# Altium schematic sheet USB.SchDoc
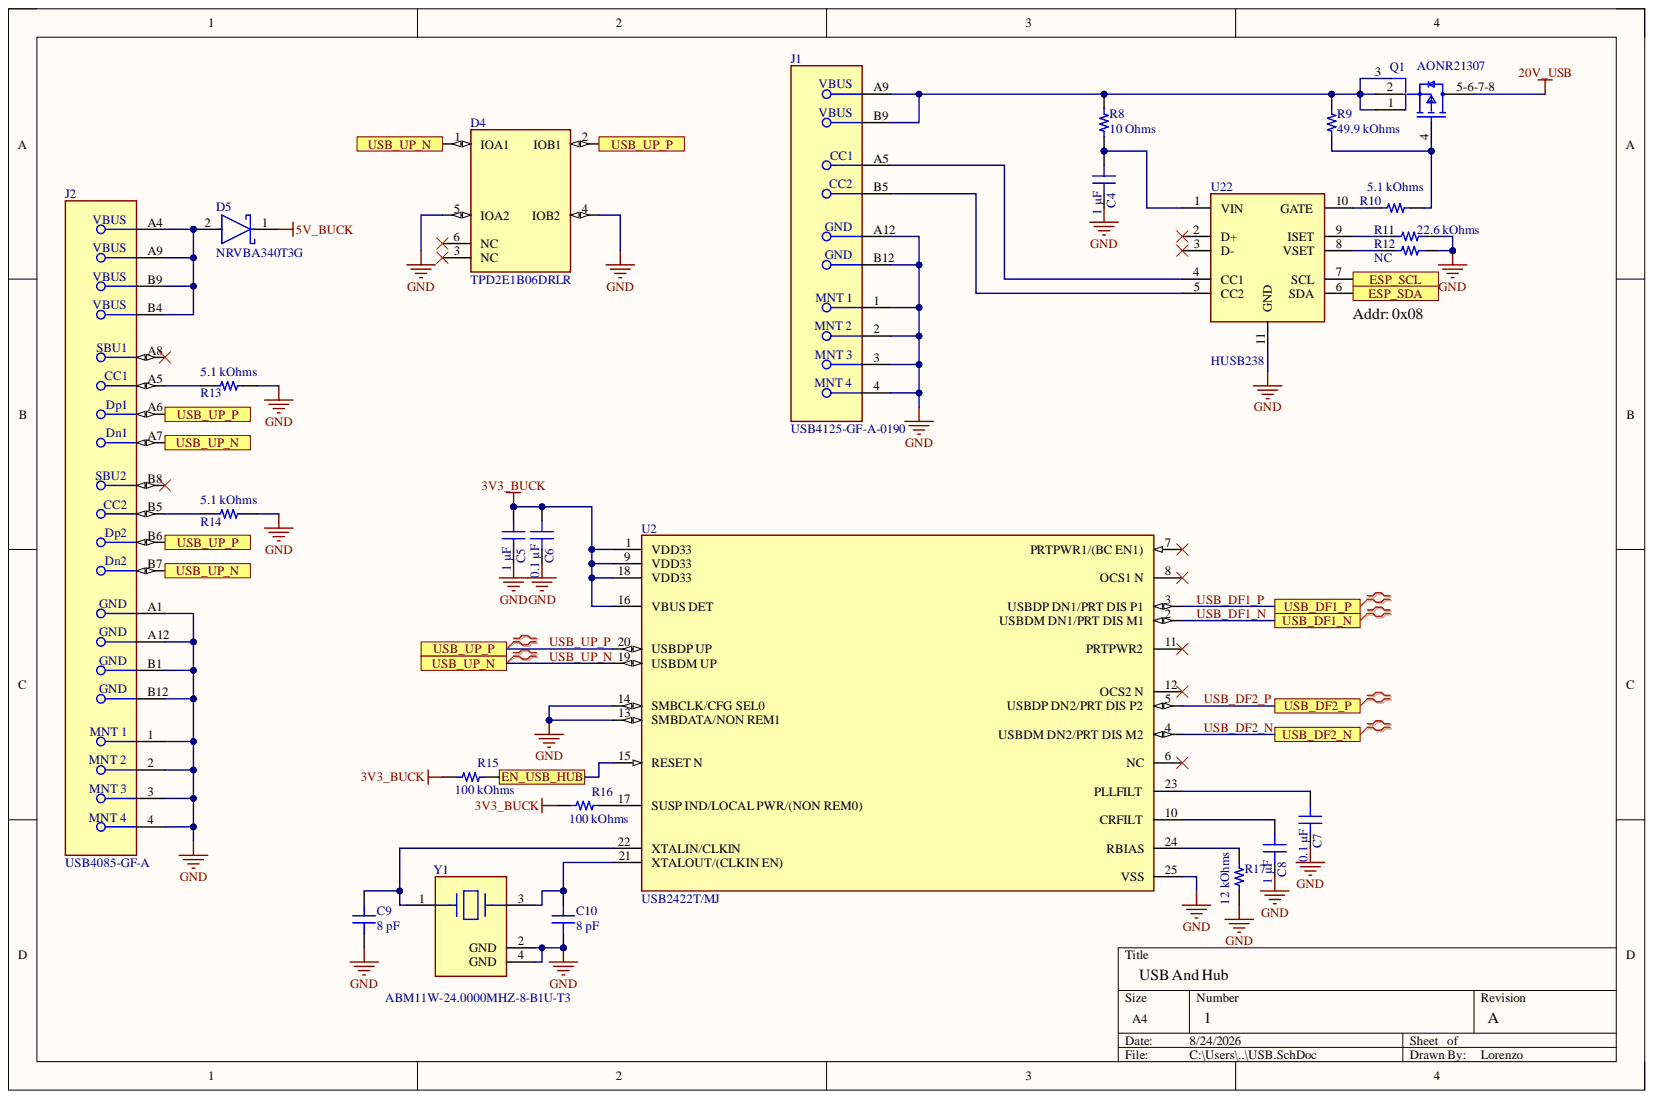
[diagram p0: USB.SchDoc]

## Parts
C4  1 µF  [CAP 0603_1608]
C5  1 µF  [CAP 0603_1608]
C6  0.1 µF  [CAP 0603_1608]
C7  0.1 µF  [CAP 0603_1608]
C8  1 µF  [CAP 0603_1608]
C9  8 pF  [CAP 0603_1608]
C10  8 pF  [CAP 0603_1608]
D4  TPD2E1B06DRLR  [FP-DRL0006A-MFG]
D5  NRVBA340T3G  [FP-403D-MFG]
J1  USB4125-GF-A-0190  [FP-USB4125-GF-A-0190-MFG]
J2  USB4085-GF-A  [FP-USB4085-GF-A-MFG]
Q1  AONR21307  [FP-DFN3x3A_8L_EP1_P-MFG]
R8  10 Ohms  [RES 0603_1608]
R9  49.9 kOhms  [RES 0603_1608]
R10  5.1 kOhms  [RES 0603_1608]
R11  22.6 kOhms  [RES 0603_1608]
R12  NC  [RES 0603_1608]
R13  5.1 kOhms  [RES 0603_1608]
R14  5.1 kOhms  [RES 0603_1608]
R15  100 kOhms  [RES 0603_1608]
R16  100 kOhms  [RES 0603_1608]
R17  12 kOhms  [RES 0603_1608]
U2  USB2422T/MJ  [FP-24-S4QFN-4x4B-0_5P-IPC_C]
U22  HUSB238  [DFN10]
Y1  ABM11W-24.0000MHZ-8-B1U-T3  [FP-ABM11W-MFG]

## Ports
EN_USB_HUB, ESP_SCL, ESP_SDA, USB_DF1_N, USB_DF1_P, USB_DF2_N, USB_DF2_P, USB_UP_N, USB_UP_P

## Nets
3V3_BUCK: C5.2 C6.2 R15.1 R16.1 U2.1(VDD33) U2.9(VDD33) U2.16(VBUS_DET) U2.18(VDD33)
5V_BUCK: D5.1
20V_USB: Q1.5-6-7-8
GND: C4.1 C5.1 C6.1 C7.1 C8.1 C9.1 C10.1 D4.4(IOB2) D4.5(IOA2) J1.1 J1.2 J1.3 J1.4 J1.A12 J1.B12 J2.1 J2.2 J2.3 J2.4 J2.A1 J2.A12 J2.B1 J2.B12 R11.2 R12.2 R13.2 R14.2 R17.1 U2.13(SMBDATA/NON_REM1) U2.14(SMBCLK/CFG_SEL0) U2.25(VSS) U22.11(GND) Y1.2(GND) Y1.4(GND)
EN_USB_HUB: R15.2 U2.15(RESET_N) ; port EN_USB_HUB
ESP_SCL: U22.7(SCL) ; port ESP_SCL
ESP_SDA: U22.6(SDA) ; port ESP_SDA
USB_DF1_N: U2.2(USBDM_DN1/PRT_DIS_M1) ; port USB_DF1_N
USB_DF1_P: U2.3(USBDP_DN1/PRT_DIS_P1) ; port USB_DF1_P
USB_DF2_N: U2.4(USBDM_DN2/PRT_DIS_M2) ; port USB_DF2_N
USB_DF2_P: U2.5(USBDP_DN2/PRT_DIS_P2) ; port USB_DF2_P
USB_UP_N: D4.1(IOA1) J2.A7 J2.B7 U2.19(USBDM_UP) ; port USB_UP_N
USB_UP_P: D4.2(IOB1) J2.A6 J2.B6 U2.20(USBDP_UP) ; port USB_UP_P
NetC4_2: C4.2 R8.1 U22.1(VIN)
NetC7_2: C7.2 U2.23(PLLFILT)
NetC8_2: C8.2 U2.10(CRFILT)
NetC9_2: C9.2 U2.22(XTALIN/CLKIN) Y1.1
NetC10_2: C10.2 U2.21(XTALOUT/(CLKIN_EN)) Y1.3
NetD5_2: D5.2 J2.A4 J2.A9 J2.B4 J2.B9
NetJ1_A5: J1.A5 U22.4(CC1)
NetJ1_A9: J1.A9 J1.B9 Q1.1 Q1.2 Q1.3 R8.2 R9.2
NetJ1_B5: J1.B5 U22.5(CC2)
NetJ2_A5: J2.A5 R13.1
NetJ2_B5: J2.B5 R14.1
NetQ1_4: Q1.4 R9.1 R10.2
NetR10_1: R10.1 U22.10(GATE)
NetR11_1: R11.1 U22.9(ISET)
NetR12_1: R12.1 U22.8(VSET)
NetR16_2: R16.2 U2.17(SUSP_IND/LOCAL_PWR/(NON_REM0))
NetR17_2: R17.2 U2.24(RBIAS)

Not connected: D4 3(NC) 6(NC); J2 A8 B8; U2 6(NC) 7(PRTPWR1/(BC_EN1)) 8(OCS1_N) 11(PRTPWR2) 12(OCS2_N); U22 2(D+) 3(D-)

## Library data of the parts
C4 C5 C8 = C1608X5R1H105K080AB: CAP CER 1UF 50V X5R 0603; manufacturer: TDK Corporation; Database Table Name: Capacitors - Ceramic - 0603; Tolerance: ±10%; Applications: General Purpose; Capacitance: 1 µF; Component Kind: Standard; componentlink1description: Datasheet; componentlink1url: https://product.tdk.com/info/en/catalog/datasheets/mlcc_commercial_general_en.pdf?ref_disty=digikey; componentlink2description: DigiKey Link; componentlink2url: https://www.digikey.com/en/products/detail/tdk-corporation/C1608X5R1H105K080AB/2732903; Device Package: 0603 (1608 Metric); Features: Low ESL; lastupdated: 09/02/2024 20:10:02; Library Name: Altium Database Library V2 Local.DbLib; Lifecycle Status: Active; Minimum Order: 1; Mounting Type: Surface Mount, MLCC; Operating Temperature: -55°C ~ 85°C; Package / Case: 0603 (1608 Metric); packaging: TapeAndReel; Part Number: C1608X5R1H105K080AB; Part Status: Active; partid: 36337; price: 0.02; series: C; Size / Dimension: 0.063" L x 0.031" W (1.60mm x 0.80mm); Supplier 1: DigiKey; Supplier Part Number 1: 445-7468-1-ND; Temperature Coefficient: X5R; Thickness (Max): 0.035" (0.90mm); Value: 1 µF; Voltage - Rated: 50V
C6 C7 = CGA3E2X7R1H104M080AE: CAP CER 0.1UF 50V X7R 0603; manufacturer: TDK Corporation; Database Table Name: Capacitors - Ceramic - 0603; Tolerance: ±20%; Applications: Automotive, Boardflex Sensitive; Capacitance: 0.1 µF; Component Kind: Standard; componentlink1description: Datasheet; componentlink1url: https://product.tdk.com/info/en/catalog/datasheets/mlcc_automotive_soft_en.pdf?ref_disty=digikey; componentlink2description: DigiKey Link; componentlink2url: https://www.digikey.com/en/products/detail/tdk-corporation/CGA3E2X7R1H104M080AE/4490595; Device Package: 0603 (1608 Metric); Features: Soft Termination; lastupdated: 09/02/2024 20:10:15; Library Name: Altium Database Library V2 Local.DbLib; Lifecycle Status: Active; Minimum Order: 1; Mounting Type: Surface Mount, MLCC; Operating Temperature: -55°C ~ 125°C; Package / Case: 0603 (1608 Metric); packaging: TapeAndReel; Part Number: CGA3E2X7R1H104M080AE; Part Status: Active; partid: 13634; price: 0.03; Ratings: AEC-Q200; series: CGA; Size / Dimension: 0.063" L x 0.031" W (1.60mm x 0.80mm); Supplier 1: DigiKey; Supplier Part Number 1: 445-15958-1-ND; Temperature Coefficient: X7R; Thickness (Max): 0.037" (0.95mm); Value: 0.1 µF; Voltage - Rated: 50V
C9 C10 = CGA3E2C0G1H080D080AA: CAP CER 8PF 50V C0G 0603; manufacturer: TDK Corporation; Database Table Name: Capacitors - Ceramic - 0603; Temperature Coefficient: C0G, NP0; Tolerance: ±0.5pF; Applications: Automotive; Capacitance: 8 pF; Component Kind: Standard; componentlink1description: Datasheet; componentlink1url: https://product.tdk.com/info/en/catalog/datasheets/mlcc_automotive_general_en.pdf?ref_disty=digikey; componentlink2description: DigiKey Link; componentlink2url: https://www.digikey.com/en/products/detail/tdk-corporation/CGA3E2C0G1H080D080AA/2443104; Device Package: 0603 (1608 Metric); lastupdated: 09/02/2024 20:10:12; Library Name: Altium Database Library V2 Local.DbLib; Lifecycle Status: Active; Minimum Order: 1; Mounting Type: Surface Mount, MLCC; Operating Temperature: -55°C ~ 125°C; Package / Case: 0603 (1608 Metric); packaging: TapeAndReel; Part Number: CGA3E2C0G1H080D080AA; Part Status: Active; partid: 15270; price: 0.01; Ratings: AEC-Q200; series: CGA; Size / Dimension: 0.063" L x 0.031" W (1.60mm x 0.80mm); Supplier 1: DigiKey; Supplier Part Number 1: 445-5626-1-ND; Thickness (Max): 0.035" (0.90mm); Value: 8 pF; Voltage - Rated: 50V
D4 = CMP-04985-000003-1: TVS DIODE 5.5V 15V 6SOT; Manufacturer: Texas Instruments; Breakdown Voltage: 7V; Direction: Bidirectional; Interface: I2C,USB,LVDS,Other; Number Of Channels: 2; Peak Pulse Current: 2.5A; Peak Pulse Power: 35W; Standoff Height: 0mm; Ambient Temperature Range High: +125°C; Ambient Temperature Range Low: -40°C; Automotive: No; Category: Diode; Clamping Voltage: 15V; Component Kind: Standard; ComponentLink2Description: Datasheet; ComponentLink2URL: http://www.ti.com/lit/ds/symlink/tpd2e1b06.pdf; Device Class L1: Circuit Protection; Device Class L2: ESD and Circuit Protection ICs; Device Class L3: unset; Digikey Description: TVS DIODE 5.5V 15V 6SOT; Height: 0.6mm; Lead Free: Yes; Library Name: Altium Content Vault; Manufacturer Part Number: TPD2E1B06DRLR; Mouser Description: ESD Suppressors / TVS Diodes 2-CH system level ESD protect device; Octopart: https://octopart.com/tpd2e1b06drlr-texas+instruments-30488860; Package: DRL0006A; Reverse Standoff Voltage: 5.5V; RoHS: TRUE; Temperature: -40°C to +125°C; Temperature Range High: +125°C; Temperature Range Low: -40°C
D5 = CMP-1055-00307-2: DIODE SCHOTTKY 40V 3A SMA; Element Configuration: Single; Forward Voltage: 450mV; Max Forward Surge Current (Ifsm): 100A; Max Reverse Current: 15mA; Average Rectified Current: 3A; Case/Package: SMA; Component Kind: Standard; Forward Current: 3A; Halogen Free: Halogen Free; Height: 2.05mm; Lead Free: Lead Free; Length: 4.57mm; Library Name: Altium Content Vault; Max Operating Temperature: 150°C; Max Repetitive Reverse Voltage (Vrrm): 40V; Max Reverse Voltage (DC): 40V; Min Operating Temperature: -55°C; Number of Pins: 2; Package Quantity: 5000; Packaging: Tape and Reel; Peak Non-Repetitive Surge Current: 100A; Radiation Hardening: No; REACH SVHC: No SVHC; RoHS Compliant: Yes; Series: -; Weight: 0.003739oz; Width: 2.92mm
J1 = CMP-245163-000004-1: CONN RCPT TYPE C 6P SMD RA; Component Kind: Standard; Library Name: Altium Content Vault
J2 = CMP-196119-000001-2: CONN RCPT USB2.0 TYPE C 24POS RA; Gender: Receptacle; Number of Positions: 16; Component Kind: Standard; Contact Material: Copper Alloy; Contact Plating: Gold; Library Name: Altium Content Vault; Orientation: Right Angle; USB Standard: USB 2.0
Q1 = CMP-83955-000002-1: MOSFET P-CH 30V 3X3 DFN; Manufacturer: Alpha & Omega Semiconductor; Continuous Drain Current: -24A; Depletion Mode: False; Drain To Source Breakdown Voltage: -30V; Drain To Source Resistance: 9.2mΩ; Gate Charge At Vgs: 35nC @ -10V; Gate To Source Voltage: 25V; Input Capacitace At Vds: 1995pF @ -15V; Max Forward Diode Voltage: -1V; Max Junction Temp: +150°C; Number Of Channels: 1; Power Dissipation: 5W; Pulse Drain Current: -82A; Reverse Recovery Charge: 20nC; Reverse Recovery Time: 13.3ns; Rthja Max: 45°C/W; Standoff Height: 0mm; Threshold Vgs Max: -2.3V; Threshold Vgs Min: -1.3V; Turn Off Delay Time: 43.5ns; Turn On Delay Time: 11ns; Typ Forward Diode Voltage: -0.7V; Automotive: No; Category: Trans; Component Kind: Standard; ComponentLink2Description: Datasheet; ComponentLink2URL: http://www.aosmd.com/res/data_sheets/AONR21307.pdf; Device Class L1: Discrete Semiconductors; Device Class L2: Transistors; Device Class L3: MOSFETs; Digikey Description: MOSFET P-CH 30V 3X3 DFN; Drain To Source Voltage: -30V; Footprint Url: http://www.aosmd.com/res/packaging_information/DFN3x3A_8L_EP1_P.pdf; Height: 0.9mm; Lead Free: Yes; Library Name: Altium Content Vault; Manufacturer Part Number: AONR21307; Number Of P Channels: 1; Octopart: https://octopart.com/aonr21307-alpha+%26+omega+semiconductor-96014004; Package: DFN3x3A_8L_EP1_P; RoHS: TRUE; Temperature: -55°C to +150°C; Temperature Range High: +150°C; Temperature Range Low: -55°C
R8 = ESR03EZPF10R0: RES SMD 10 OHM 1% 1/4W 0603; Manufacturer: Rohm Semiconductor; Database Table Name: Resistors - Surface Mount - 0603; Power (Watts): 0.25W, 1/4W; Temperature Coefficient: ±100ppm/°C; Component Kind: Standard; ComponentLink1Description: Datasheet; ComponentLink1URL: https://www.rohm.com/datasheet/ESR01MZPF/esr-e; ComponentLink2Description: DigiKey Link; ComponentLink2URL: https://www.digikey.com/en/products/detail/rohm-semiconductor/ESR03EZPF10R0/1983431; Composition: Thick Film; Device Package: 0603 (1608 Metric); Features: Automotive AEC-Q200, Pulse Withstanding; Height - Seated (Max): 0.022" (0.55mm); LastUpdated: 09/02/2024 20:43:34; Library Name: Altium Database Library V2 Local.DbLib; Lifecycle Status: Active; Minimum Order: 1; Number of Terminations: 2; Operating Temperature: -55°C ~ 155°C; Package / Case: 0603 (1608 Metric); Packaging: TapeAndReel; Part Number: ESR03EZPF10R0; Part Status: Active; PartId: 5471; Price: 0.02; Ratings: AEC-Q200; Resistance: 10 Ohms; Series: ESR; Size / Dimension: 0.063" L x 0.031" W (1.60mm x 0.80mm); Supplier 1: DigiKey; Supplier Device Package: 0603; Supplier Part Number 1: RHM10.0ADCT-ND; Tolerance: ±1%; Value: 10 Ohms
R9 = RMCF0603FT49K9: RES 49.9K OHM 1% 1/10W 0603; Manufacturer: Stackpole Electronics Inc; Database Table Name: Resistors - Surface Mount - 0603; Power (Watts): 0.1W, 1/10W; Temperature Coefficient: ±100ppm/°C; Component Kind: Standard; ComponentLink1Description: Datasheet; ComponentLink1URL: https://www.seielect.com/catalog/sei-rmcf_rmcp.pdf; ComponentLink2Description: DigiKey Link; ComponentLink2URL: https://www.digikey.com/en/products/detail/stackpole-electronics-inc/RMCF0603FT49K9/1760907; Composition: Thick Film; Device Package: 0603 (1608 Metric); Features: Automotive AEC-Q200; Height - Seated (Max): 0.022" (0.55mm); LastUpdated: 09/02/2024 20:43:14; Library Name: Altium Database Library V2 Local.DbLib; Lifecycle Status: Active; Minimum Order: 1; Number of Terminations: 2; Operating Temperature: -55°C ~ 155°C; Package / Case: 0603 (1608 Metric); Packaging: CutTape; Part Number: RMCF0603FT49K9; Part Status: Active; PartId: 10596; Price: 0.01; Ratings: AEC-Q200; Resistance: 49.9 kOhms; Series: RMCF; Size / Dimension: 0.061" L x 0.031" W (1.55mm x 0.80mm); Supplier 1: DigiKey; Supplier Device Package: 0603; Supplier Part Number 1: RMCF0603FT49K9CT-ND; Tolerance: ±1%; Value: 49.9 kOhms
R10 R13 R14 = RMCF0603FT5K10: RES 5.1K OHM 1% 1/10W 0603; Manufacturer: Stackpole Electronics Inc; Database Table Name: Resistors - Surface Mount - 0603; Power (Watts): 0.1W, 1/10W; Temperature Coefficient: ±100ppm/°C; Component Kind: Standard; ComponentLink1Description: Datasheet; ComponentLink1URL: https://www.seielect.com/catalog/sei-rmcf_rmcp.pdf; ComponentLink2Description: DigiKey Link; ComponentLink2URL: https://www.digikey.com/en/products/detail/stackpole-electronics-inc/RMCF0603FT5K10/1760908; Composition: Thick Film; Device Package: 0603 (1608 Metric); Features: Automotive AEC-Q200; Height - Seated (Max): 0.022" (0.55mm); LastUpdated: 09/02/2024 20:43:14; Library Name: Altium Database Library V2 Local.DbLib; Lifecycle Status: Active; Minimum Order: 1; Number of Terminations: 2; Operating Temperature: -55°C ~ 155°C; Package / Case: 0603 (1608 Metric); Packaging: CutTape; Part Number: RMCF0603FT5K10; Part Status: Active; PartId: 10617; Price: 0.01; Ratings: AEC-Q200; Resistance: 5.1 kOhms; Series: RMCF; Size / Dimension: 0.061" L x 0.031" W (1.55mm x 0.80mm); Supplier 1: DigiKey; Supplier Device Package: 0603; Supplier Part Number 1: RMCF0603FT5K10CT-ND; Tolerance: ±1%; Value: 5.1 kOhms
R11 = RC0603FR-0722K6L: RES 22.6K OHM 1% 1/10W 0603; Manufacturer: Yageo; Database Table Name: Resistors - Surface Mount - 0603; Power (Watts): 0.1W, 1/10W; Temperature Coefficient: ±100ppm/°C; Component Kind: Standard; ComponentLink1Description: Datasheet; ComponentLink1URL: https://www.yageo.com/upload/media/product/productsearch/datasheet/rchip/PYu-RC_Group_51_RoHS_L_10.pdf; ComponentLink2Description: DigiKey Link; ComponentLink2URL: https://www.digikey.com/en/products/detail/yageo/RC0603FR-0722K6L/727060; Composition: Thick Film; Device Package: 0603 (1608 Metric); Features: Moisture Resistant; Height - Seated (Max): 0.022" (0.55mm); LastUpdated: 09/02/2024 20:43:06; Library Name: Altium Database Library V2 Local.DbLib; Lifecycle Status: Active; Minimum Order: 1; Number of Terminations: 2; Operating Temperature: -55°C ~ 155°C; Package / Case: 0603 (1608 Metric); Packaging: CutTape; Part Number: RC0603FR-0722K6L; Part Status: Active; PartId: 12540; Price: 0.01; Resistance: 22.6 kOhms; Series: RC_L; Size / Dimension: 0.063" L x 0.031" W (1.60mm x 0.80mm); Supplier 1: DigiKey; Supplier Device Package: 0603; Supplier Part Number 1: 311-22.6KHRCT-ND; Tolerance: ±1%; Value: 22.6 kOhms
R12 = HCJ0603ZT0R00: RES 0 OHM JUMPER 1/4W 0603; Manufacturer: Stackpole Electronics Inc; Database Table Name: Resistors - Surface Mount - 0603; Power (Watts): 0.25W, 1/4W; Resistance: 0 Ohms; Component Kind: Standard; ComponentLink1Description: Datasheet; ComponentLink1URL: https://www.seielect.com/catalog/sei-hcj.pdf; ComponentLink2Description: DigiKey Link; ComponentLink2URL: https://www.digikey.com/en/products/detail/stackpole-electronics-inc/HCJ0603ZT0R00/4357660; Composition: Metal Foil; Device Package: 0603 (1608 Metric); Features: Automotive AEC-Q200; Height - Seated (Max): 0.018" (0.45mm); LastUpdated: 09/02/2024 20:43:15; Library Name: Altium Database Library V2 Local.DbLib; Lifecycle Status: Active; Minimum Order: 1; Number of Terminations: 2; Operating Temperature: -55°C ~ 155°C; Package / Case: 0603 (1608 Metric); Packaging: TapeAndReel; Part Number: HCJ0603ZT0R00; Part Status: Active; PartId: 10189; Price: 0.06; Ratings: AEC-Q200; Series: HCJ; Size / Dimension: 0.061" L x 0.031" W (1.55mm x 0.80mm); Supplier 1: DigiKey; Supplier Device Package: 0603; Supplier Part Number 1: HCJ0603ZT0R00CT-ND; Tolerance: Jumper; Value: NC
R15 R16 = SG73P1JTTD1003F: RES 100K OHM 1% 1/3W 0603; Manufacturer: KOA Speer Electronics, Inc.; Database Table Name: Resistors - Surface Mount - 0603; Power (Watts): 0.333W, 1/3W; Temperature Coefficient: ±100ppm/°C; Component Kind: Standard; ComponentLink1Description: Datasheet; ComponentLink1URL: https://www.koaspeer.com/pdfs/SG73P.pdf; ComponentLink2Description: DigiKey Link; ComponentLink2URL: https://www.digikey.com/en/products/detail/koa-speer-electronics-inc/SG73P1JTTD1003F/10188341; Composition: Thick Film; Device Package: 0603 (1608 Metric); Features: Automotive AEC-Q200, Pulse Withstanding; Height - Seated (Max): 0.022" (0.55mm); LastUpdated: 09/02/2024 20:40:28; Library Name: Altium Database Library V2 Local.DbLib; Lifecycle Status: Active; Minimum Order: 1; Number of Terminations: 2; Operating Temperature: -55°C ~ 155°C; Package / Case: 0603 (1608 Metric); Packaging: TapeAndReel; Part Number: SG73P1JTTD1003F; PartId: 83707; Price: 0.01; Ratings: AEC-Q200; Resistance: 100 kOhms; Series: SG73P; Size / Dimension: 0.063" L x 0.031" W (1.60mm x 0.80mm); Supplier 1: DigiKey; Supplier Device Package: 0603; Supplier Part Number 1: 2019-SG73P1JTTD1003FCT-ND; Tolerance: ±1%; Value: 100 kOhms
R17 = CRCW060312K0FKEAHP: RES SMD 12K OHM 1% 1/3W 0603; Manufacturer: Vishay Dale; Database Table Name: Resistors - Surface Mount - 0603; Power (Watts): 0.333W, 1/3W; Temperature Coefficient: ±100ppm/°C; Component Kind: Standard; ComponentLink1Description: Datasheet; ComponentLink1URL: http://www.vishay.com/docs/20043/crcwhpe3.pdf; ComponentLink2Description: DigiKey Link; ComponentLink2URL: https://www.digikey.com/en/products/detail/vishay-dale/CRCW060312K0FKEAHP/2226294; Composition: Thick Film; Device Package: 0603 (1608 Metric); Features: Automotive AEC-Q200, Pulse Withstanding; Height - Seated (Max): 0.022" (0.55mm); LastUpdated: 09/02/2024 20:43:11; Library Name: Altium Database Library V2 Local.DbLib; Lifecycle Status: Active; Minimum Order: 1; Number of Terminations: 2; Operating Temperature: -55°C ~ 155°C; Package / Case: 0603 (1608 Metric); Packaging: TapeAndReel; Part Number: CRCW060312K0FKEAHP; Part Status: Active; PartId: 11340; Price: 0.01; Ratings: AEC-Q200; Resistance: 12 kOhms; Series: CRCW-HP; Size / Dimension: 0.063" L x 0.033" W (1.60mm x 0.85mm); Supplier 1: DigiKey; Supplier Device Package: 0603; Supplier Part Number 1: 541-12.0KSCT-ND; Tolerance: ±1%; Value: 12 kOhms
U2 = CMP-06284-000052-1: IC HUB CTLR USB 2.0 24QFN; Data Rate: 480Mbps; Number of Ports: 2; Case/Package: QFN; Component Kind: Standard; Interface: USB; Lead Free: Lead Free; Library Name: Altium Content Vault; Max Operating Temperature: 70°C; Min Operating Temperature: 0°C; Number of Pins: 24; Package Quantity: 5000; Packaging: Tape and Reel; RoHS Compliant: Yes; Series: -
Y1 = CMP-27762-001449-1: Crystal 24MHz ±10ppm 8pF SMD-4 2mm x 1.6mm; ESR (Equivalent Series Resistance): 100R; Case/Package: SMD/SMT; Component Kind: Standard; Frequency: 24MHz; Frequency Stability: 10ppm; Frequency Tolerance: 10ppm; Library Name: Altium Content Vault; Load Capacitance: 8pF; Max Operating Temperature: 70°C; Min Operating Temperature: -20°C; Mount: Surface Mount; Packaging: Tape and Reel; RoHS Compliant: Yes
U22 = HUSB238: Component Kind: Standard; Library Name: Lib.SchLib
